# Altium schematic sheet Infrared-Mini_CM.SchDoc
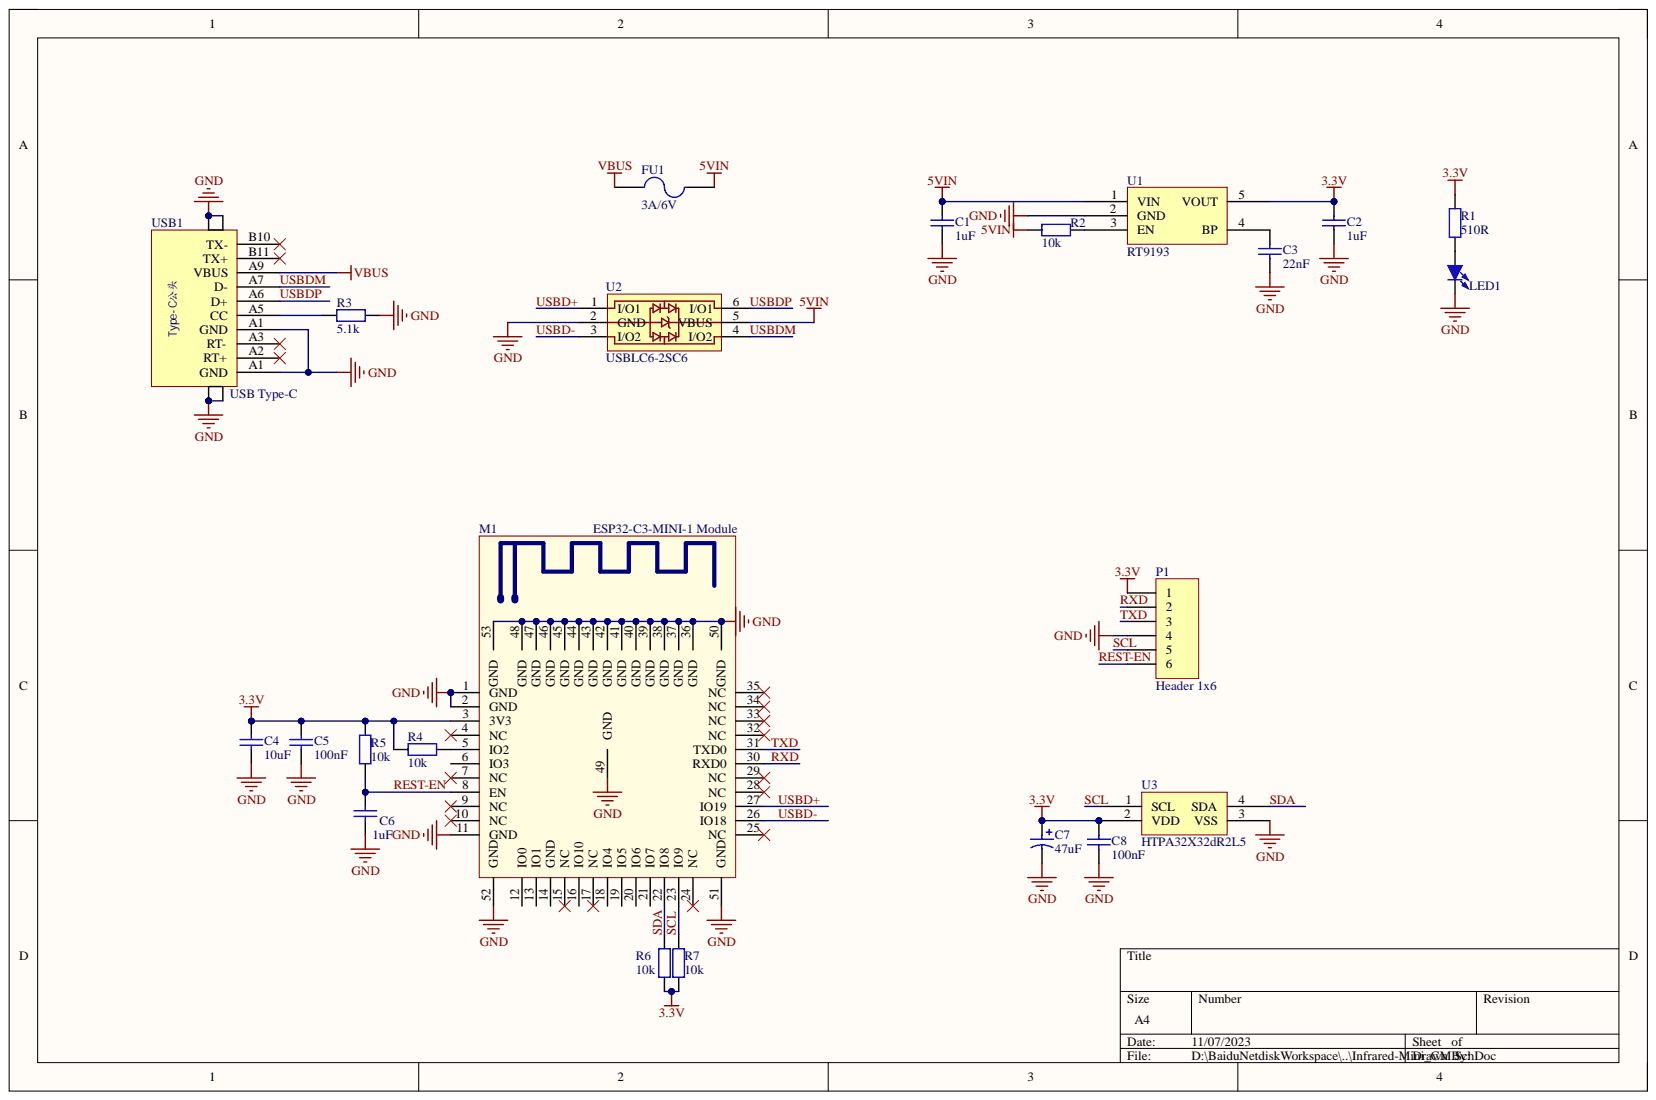
[diagram p0: Infrared-Mini_CM.SchDoc]

## Parts
C1  1uF  [C-RAD-0603]
C2  1uF  [C-RAD-0603]
C3  22nF  [C-RAD-0402]
C4  10uF  [C-RAD-0603]
C5  100nF  [C-RAD-0402]
C6  1uF  [C-RAD-0402]
C7  47uF  [C-CD A(3216-18)]
C8  100nF  [C-RAD-0402]
FU1  3A/6V  [Fu 1206]
LED1  LED-SMD  [LED 0402R]  (value not drawn)
M1  ESP32-C3-MINI-1 Module  [ESP32-C3-MINI-1 Module]
P1  Header 1x6  [TP-1.27mmx6]
R1  510R  [RES-0402 (1/16W)]
R2  10k  [RES-0402 (1/16W)]
R3  5.1k  [RES-0402 (1/16W)]
R4  10k  [RES-0402 (1/16W)]
R5  10k  [RES-0402 (1/16W)]
R6  10k  [RES-0402 (1/16W)]
R7  10k  [RES-0402 (1/16W)]
U1  RT9193  [SOT23-5]
U2  USBLC6-2SC6  [SOT23-6L]
U3  HTPA32X32dR2L5  [HTPA32X32dR2L5]
USB1  USB Type-C  [USB_TYPE-C_M_9P_1]

## Nets
3.3V: C2.2 C4.2 C5.2 C7.1 C8.2 M1.3(3V3) P1.1 R1.2 R4.2 R5.1 R6.1 R7.1 U1.5(VOUT) U3.2(VDD)
5VIN: C1.2 FU1.2 R2.1 U1.1(VIN) U2.5(VBUS)
GND: C1.1 C2.1 C3.1 C4.1 C5.1 C6.1 C7.2 C8.1 LED1.2 M1.1(GND) M1.2(GND) M1.11(GND) M1.36(GND) M1.37(GND) M1.38(GND) M1.39(GND) M1.40(GND) M1.41(GND) M1.42(GND) M1.43(GND) M1.44(GND) M1.45(GND) M1.46(GND) M1.47(GND) M1.48(GND) M1.49(GND) M1.50(GND) M1.51(GND) M1.52(GND) M1.53(GND) P1.4 R3.2 U1.2(GND) U2.2(GND) U3.3(VSS) USB1.0 USB1.A1(GND)
VBUS: FU1.1 USB1.A9(VBUS)
REST-EN: C6.2 M1.8(EN) P1.6 R5.2
RXD: M1.30(RXD0) P1.2
SCL: M1.23(IO9) P1.5 R7.2 U3.1(SCL)
SDA: M1.22(IO8) R6.2 U3.4(SDA)
TXD: M1.31(TXD0) P1.3
USBD+: M1.27(IO19) U2.1(I/O1)
USBD-: M1.26(IO18) U2.3(I/O2)
USBDM: U2.4(I/O2) USB1.A7(D-)
USBDP: U2.6(I/O1) USB1.A6(D+)
NetC3_2: C3.2 U1.4(BP)
NetLED1_1: LED1.1 R1.1
NetM1_5: M1.5(IO2) R4.1
NetR2_2: R2.2 U1.3(EN)
NetR3_1: R3.1 USB1.A5(CC)

Not connected: M1 4(NC) 6(IO3) 7(NC) 9(NC) 10(NC) 12(IO0) 13(IO1) 14(GND) 15(NC) 16(IO10) 17(NC) 18(IO4) 19(IO5) 20(IO6) 21(IO7) 24(NC) 25(NC) 28(NC) 29(NC) 32(NC) 33(NC) 34(NC) 35(NC); USB1 A2(RT+) A3(RT-) B10(TX-) B11(TX+)

## Library data of the parts
C1-C6 C8 = Cap: Capacitor; Component Kind: Standard; LatestRevisionDate: 17-Jul-2002; LatestRevisionNote: Re-released for DXP Platform.; Library Name: Master Li.SchLib; PackageDescription: Radial Cap, Thru-Hole; 2 Leads; 0.3 in Pin Spacing; PackageReference: RAD-0.3; Published: 8-Jun-2000; Publisher: Altium Limited
  C1 C2 C6: Value: 1uF
  C3: Value: 22nF
  C4: Value: 10uF
  C5 C8: Value: 100nF
C7 = Cap(tantalum): tantalum capacitor; Component Kind: Standard; Library Name: Master Li.SchLib; Value: 47uF
FU1 = Fuse: 保险丝; Component Kind: Standard; LatestRevisionDate: 17-Jul-2002; LatestRevisionNote: Re-released for DXP Platform.; Library Name: Master Li.SchLib; PackageReference: PIN-W2/E2.8; Published: 8-Jun-2000; Publisher: Altium Limited
LED1 = LED-SMD: 贴片LED; 版权归属: Master Li; BOM名称: 贴片LED; Component Kind: Standard; Library Name: Master Li.SchLib; 学习资源: https://shop237247974.taobao.com/
M1 = ESP32-C3-MINI-1 Module: 2.4 GHz WiFi(802.11 b/g/n)+蓝牙®5的小尺寸模组，4MB flash，15 个GPIO; Component Kind: Standard; Library Name: Master Li.SchLib
P1 = Header 1x6: Header, 1x6-Pin; Component Kind: Standard; LatestRevisionDate: 17-Jul-2002; LatestRevisionNote: Re-released for DXP Platform.; Library Name: Master Li.SchLib; Publisher: Altium Limited
R1-R7 = Res: Resistor; Component Kind: Standard; LatestRevisionDate: 17-Jul-2002; LatestRevisionNote: Re-released for DXP Platform.; Library Name: Master Li.SchLib; PackageDescription: Axial Device, Thru-Hole; 2 Leads; 0.4 in Pin Spacing; PackageReference: AXIAL-0.4; Published: 8-Jun-2000; Publisher: Altium Limited
  R1: Value: 510R
  R2 R4-R7: Value: 10k
  R3: Value: 5.1k
U1 = HB3017-3.3V: HB3017-3.3V / RT9193 / DE=A1D; Component Kind: Standard; Library Name: Master Li.SchLib
U2 = USBLC6-2SC6: 静电放电(ESD)保护器件; Component Kind: Standard; Library Name: Master Li.SchLib
U3 = HTPA32X32dR2L5: 海曼HEIMANN 红外温度传感器; Component Kind: Standard; Library Name: Master Li.SchLib
USB1 = USB_Type-C_M_9P: USB Type-C 9P 公头; Component Kind: Standard; Library Name: Master Li.SchLib
